# Altium schematic sheet DCDC_pump.SchDoc(DCDC_pump)
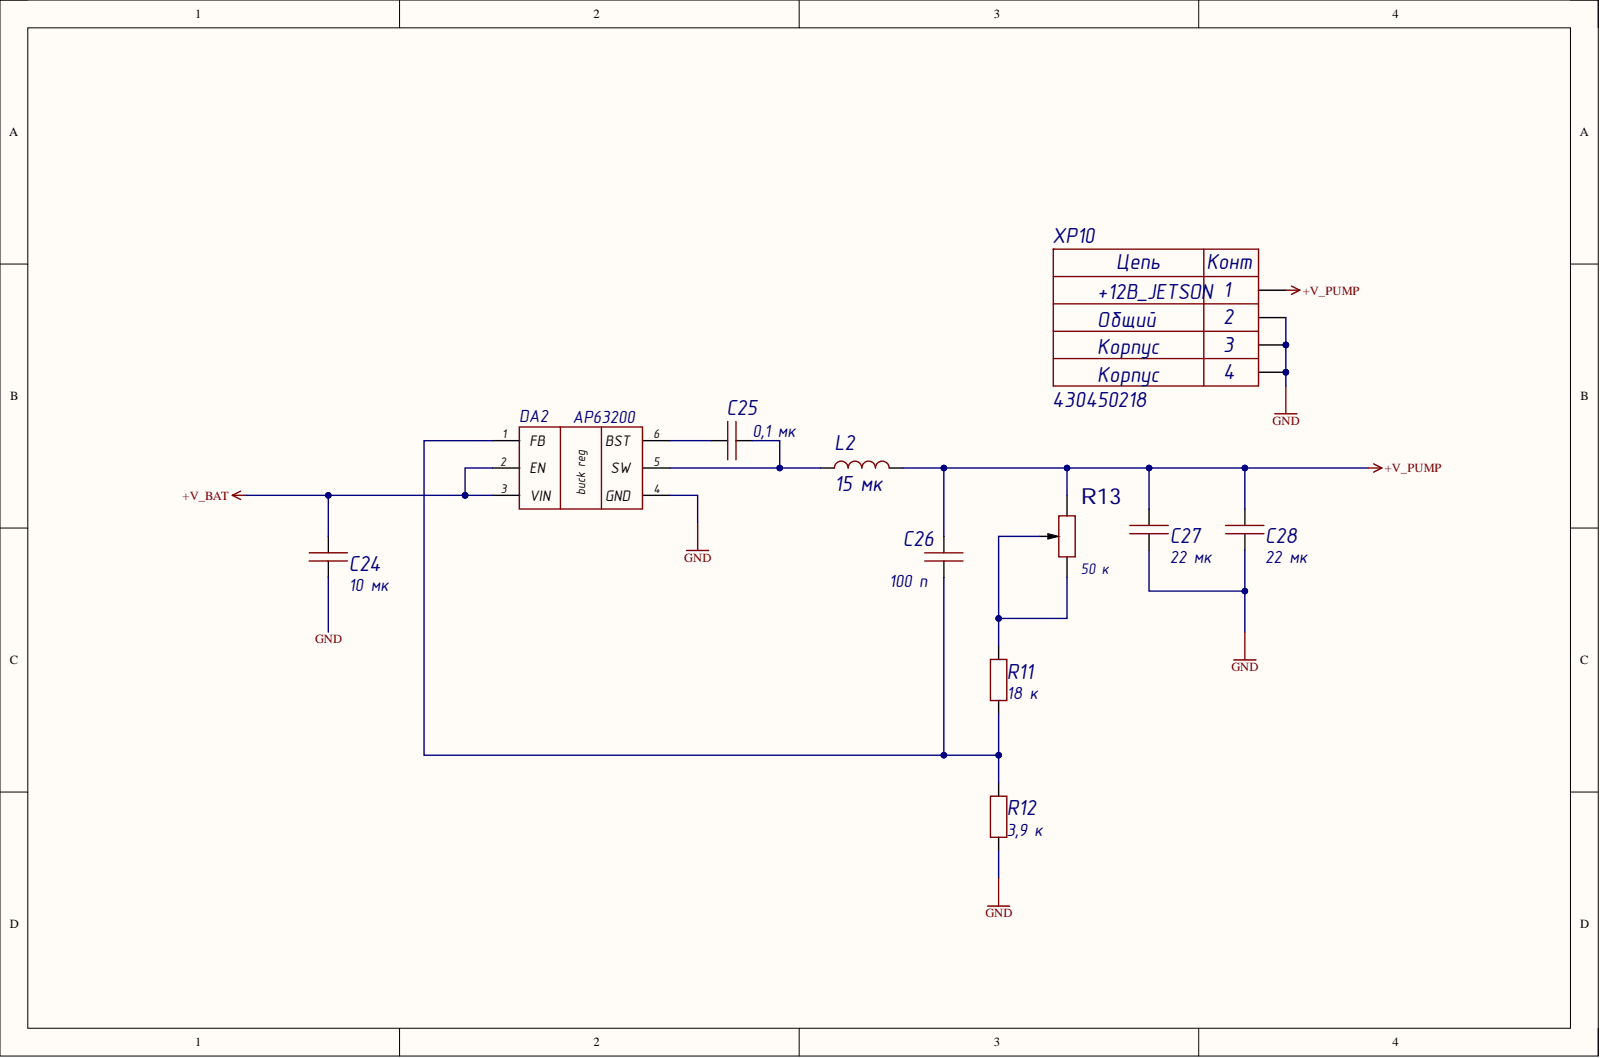
[diagram p0: DCDC_pump.SchDoc]

## Parts
C24  value 10 мк  [1210C]  (hidden comment: Capacitor)
C25  value 0,1 мк  Tolerance: 0,1  [0603C]  (hidden comment: Capacitor)
C26  value 100 п  [0603C]  (hidden comment: Capacitor)
C27  value 22 мк  [1210C]  (hidden comment: Capacitor)
C28  value 22 мк  [1210C]  (hidden comment: Capacitor)
DA2  AP63200  [TSOT26]
L2  value 15 мк  [SP43]  (hidden comment: SP43-150K)
R11  value 18 к  [0603R]  (hidden comment: Resistor)
R12  value 3,9 к  [0603R]  (hidden comment: Resistor)
R13  value 50 к  [3mm_trim]  (hidden comment: TC33X-2-503E)
XP10  430450218  Value1: +12В_JETSON  Value2: Общий  Value3: Корпус  [Molex_430450218]

## Nets
+V_BAT: C24.2 DA2.2(EN) DA2.3(VIN)
+V_PUMP: C26.2 C27.2 C28.2 L2.2 R13.3 XP10.1
GND: C24.1 C27.1 C28.1 DA2.4(GND) R12.1 XP10.0 XP10.2
NetC25_1: C25.1 DA2.6(BST)
NetC25_2: C25.2 DA2.5(SW) L2.1
NetC26_1: C26.1 DA2.1(FB) R11.1 R12.2
NetR11_2: R11.2 R13.1 R13.2

## Library data of the parts
C24 = Capacitor_10u_10_35V_X7R_1210C: Конденсатор; Capacity (nF): 10000; Database Table Name: Capacitors_ceramic$; Dielectric: X7R; Library Path2: Schematic\Capacitor.SchLib; Tolerance: 0,1; Voltage (V): 35; Component Kind: Standard; Library Name: Hydrolib.DbLib; Part Number: Capacitor_10u_10_35V_X7R_1210C
C25 = Capacitor_0,1u_10_50V_X7R_0603C: Конденсатор; Capacity (nF): 100; Database Table Name: Capacitors_ceramic$; Dielectric: X7R; Library Path2: Schematic\Capacitor.SchLib; Voltage (V): 50; Component Kind: Standard; Library Name: Hydrolib.DbLib; Part Number: Capacitor_0,1u_10_50V_X7R_0603C
C26 = Capacitor_100p_10_50V_X7R_0603C: Конденсатор; Capacity (nF): 0,1; Database Table Name: Capacitors_ceramic$; Dielectric: X7R; Library Path2: Schematic\Capacitor.SchLib; Voltage (V): 50; Component Kind: Standard; Library Name: Hydrolib.DbLib; Part Number: Capacitor_100p_10_50V_X7R_0603C; Tolerance: 0,1
C27 C28 = Capacitor_22u_10_25V_X7R_1210C: Конденсатор; Capacity (nF): 22000; Database Table Name: Capacitors_ceramic$; Dielectric: X7R; Library Path2: Schematic\Capacitor.SchLib; Tolerance: 0,1; Voltage (V): 25; Component Kind: Standard; Library Name: Hydrolib.DbLib; Part Number: Capacitor_22u_10_25V_X7R_1210C
DA2 = AP63200: DC-DC понижающий преобразователь, Vin: 3.8 - 40 В, adj, 2 А; Database Table Name: Chips$; Component Kind: Standard; Library Name: Hydrolib.DbLib; Part Number: AP63200
L2 = SP43-150K: Индуктивность на 15 мкГн, номинальный ток 1,3 А; Current (A): 1,3; Database Table Name: Inductance$; Inductance (μH): 15; Component Kind: Standard; Library Name: Hydrolib.DbLib; Part Number: SP43-150K
R11 = Resistor_18k_1_0603: Резистор номиналом 18 кОм и точностью 1%; Database Table Name: Resistors$; Component Kind: Standard; Library Name: Hydrolib.DbLib; Part Number: Resistor_18k_1_0603; Tolerance: 1%
R12 = Resistor_3.9k_1_0603: Резистор номиналом 3,9 кОм и точностью 1%; Database Table Name: Resistors$; Component Kind: Standard; Library Name: Hydrolib.DbLib; Part Number: Resistor_3.9k_1_0603; Tolerance: 1%
R13 = TC33X-2-503E: Потенциометр однооборотный керметный 50кОм 0.1Вт ±25% SMD лента на катушке; Database Table Name: Resistors$; Tolerance: 50%; Component Kind: Standard; Library Name: Hydrolib.DbLib; Part Number: TC33X-2-503E
XP10 = Molex_430450218: Разъем типа провод-плата, 3 мм, 2 контакт(-ов), Штыревой Разъем, Серия Micro-Fit для поверхностного монтажа; Database Table Name: Connectors$; Component Kind: Standard; Library Name: Hydrolib.DbLib; Part Number: Molex_430450218; Value4: Корпус
